# Altium schematic sheet Sheet1 (3).SchDoc("Sheet1 (3)")
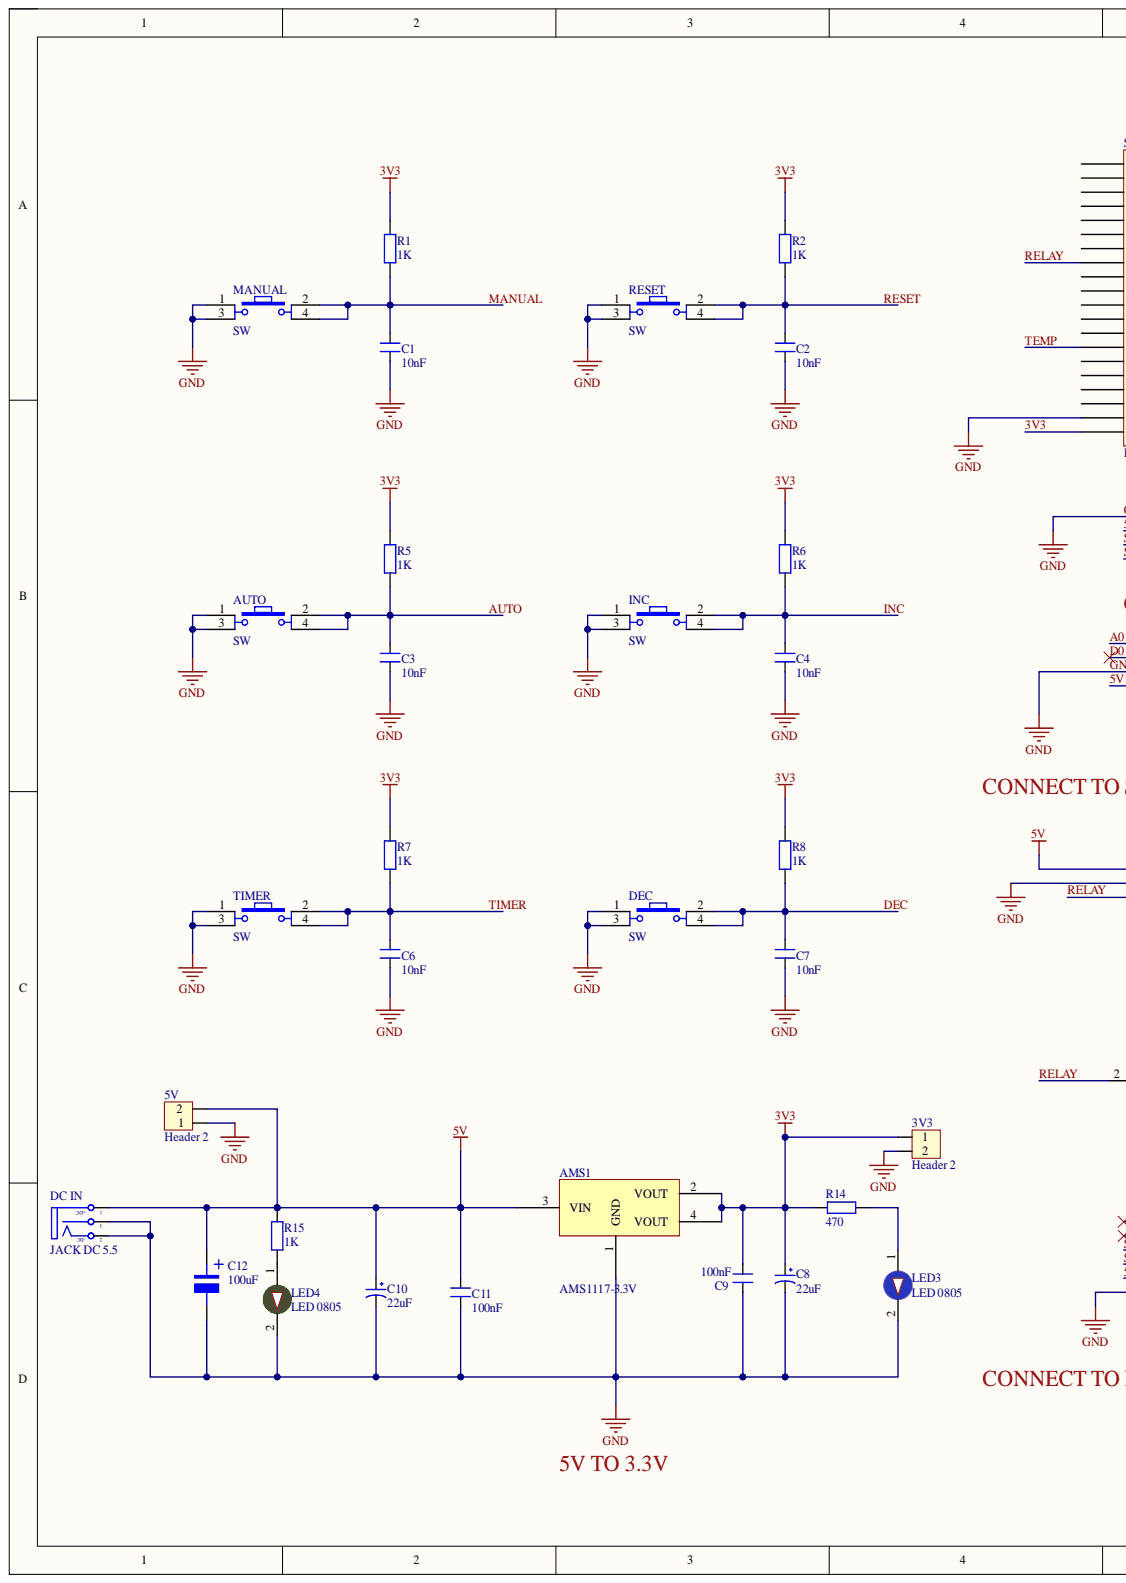
[diagram p0: Sheet1 , left]
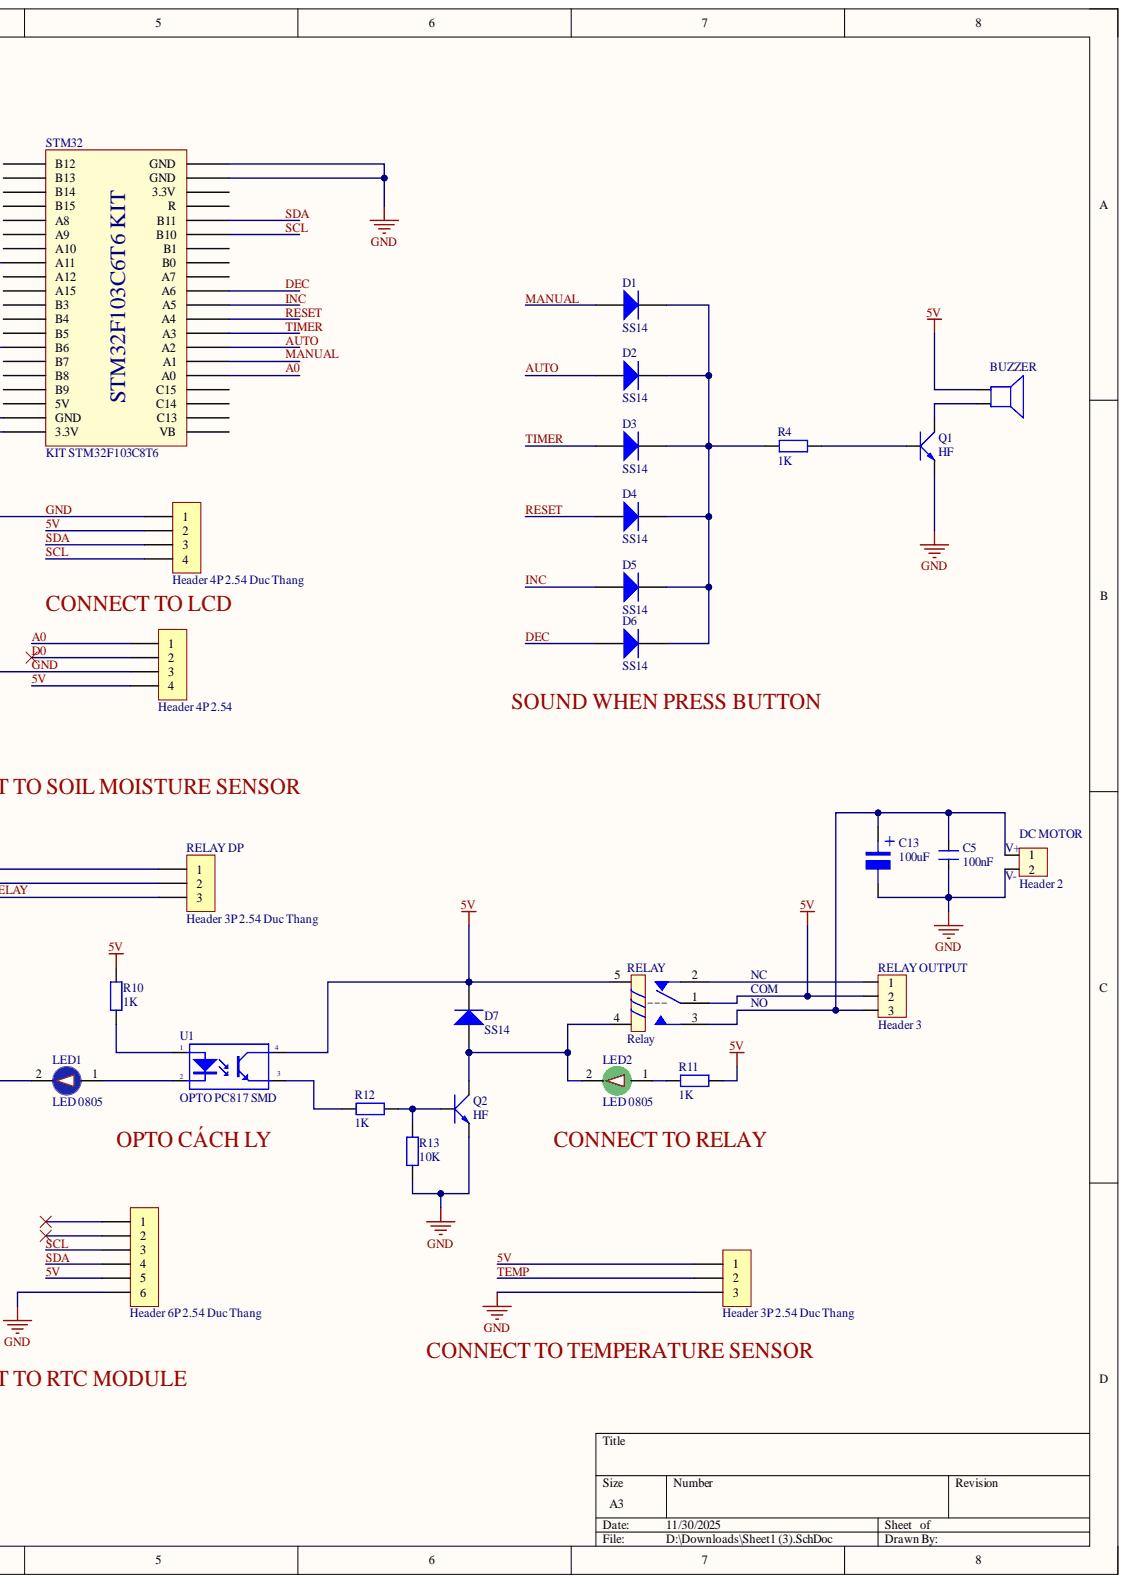
[diagram p1: Sheet1 , right]

## Parts
3V3  Header 2  [HED2]
5V  Header 2  [HED2]
AMS1  AMS1117-3.3V  [SOT223]
AUTO  SW  [SW4]
BUZZER  (no value)  [Coi Chip Speacker]
C1  10nF  [SM-0805]
C2  10nF  [SM-0805]
C3  10nF  [SM-0805]
C4  10nF  [SM-0805]
C5  100nF  [SM-0805]
C6  10nF  [SM-0805]
C7  10nF  [SM-0805]
C8  22uF  [tu tantalum smd3528]
C9  100nF  [SM-0805]
C10  22uF  [tu tantalum smd3528]
C11  100nF  [SM-0805]
C12  100uF  [tu nhom 6.3 (d)]
C13  100uF  [tu nhom 6.3 (d)]
D1  SS14  [DIODE SMA]
D2  SS14  [DIODE SMA]
D3  SS14  [DIODE SMA]
D4  SS14  [DIODE SMA]
D5  SS14  [DIODE SMA]
D6  SS14  [DIODE SMA]
D7  SS14  [DIODE SMA]
DC_IN  JACK DC 5.5  [JACK DC 5.5]
DC_MOTOR  Header 2  [HED2]
DEC  SW  [SW4]
DS3231  Header 6P 2.54 Duc Thang  [XH2.54M-6]
INC  SW  [SW4]
LCD_I2C  Header 4P 2.54 Duc Thang  [XH2.54M-4]
LED1  LED 0805  [LED-0805-Blue]
LED2  LED 0805  [LED-0805-Green]
LED3  LED 0805  [LED-0805-Blue]
LED4  LED 0805  [LED-0805-Green]
MANUAL  SW  [SW4]
MOISTURE  Header 4P 2.54  [XH2.54M-4]
Q1  HF  [SOT-23]
Q2  HF  [SOT-23]
R1  1K  [SM-0805]
R2  1K  [SM-0805]
R4  1K  [SM-0805]
R5  1K  [SM-0805]
R6  1K  [SM-0805]
R7  1K  [SM-0805]
R8  1K  [SM-0805]
R10  1K  [SM-0805]
R11  1K  [SM-0805]
R12  1K  [SM-0805]
R13  10K  [SM-0805]
R14  470  [SM-0805]
R15  1K  [SM-0805]
RELAY  Relay  [Relay 5 Chan SRD]
RELAY_DP  Header 3P 2.54 Duc Thang  [XH2.54M-3]
RELAY_OUTPUT  Header 3  [HED3]
RESET  SW  [SW4]
STM32  KIT STM32F103C8T6  [STM32F103 KIT]
TEMP  Header 3P 2.54 Duc Thang  [XH2.54M-3]
TIMER  SW  [SW4]
U1  OPTO PC817 SMD  value PC817  [SOP4]

## Nets
3V3: 3V3.1 AMS1.2(VOUT) AMS1.4(VOUT) C8.1 C9.2 R1.2 R2.2 R5.2 R6.2 R7.2 R8.2 R14.2 STM32.20(3.3V)
5V: 5V.2 AMS1.3(VIN) BUZZER.1 C10.1 C11.2 C12.1 D7.2 DC_IN.1 DS3231.5 LCD_I2C.2 MOISTURE.4 R10.2 R11.1 R15.1 RELAY.1 RELAY.5 RELAY_DP.1 RELAY_OUTPUT.2 TEMP.1 U1.4
A0: MOISTURE.1 STM32.25(A0)
AUTO: AUTO.2 AUTO.4 C3.2 D2.1 R5.1 STM32.27(A2)
D0: MOISTURE.2
DEC: C7.2 D6.1 DEC.2 DEC.4 R8.1 STM32.31(A6)
GND: 3V3.2 5V.1 AMS1.1(GND) AUTO.1 AUTO.3 C1.1 C2.1 C3.1 C4.1 C5.1 C6.1 C7.1 C8.2 C9.1 C10.2 C11.1 C12.2 C13.2 DC_IN.2 DC_IN.3 DC_MOTOR.2 DEC.1 DEC.3 DS3231.6 INC.1 INC.3 LCD_I2C.1 LED3.2 LED4.2 MANUAL.1 MANUAL.3 MOISTURE.3 Q1.2 Q2.2 R13.2 RELAY_DP.2 RESET.1 RESET.3 STM32.19(GND) STM32.39(GND) STM32.40(GND) TEMP.3 TIMER.1 TIMER.3
INC: C4.2 D5.1 INC.2 INC.4 R6.1 STM32.30(A5)
MANUAL: C1.2 D1.1 MANUAL.2 MANUAL.4 R1.1 STM32.26(A1)
RELAY: LED1.2 RELAY_DP.3 STM32.8(A11)
RESET: C2.2 D4.1 R2.1 RESET.2 RESET.4 STM32.29(A4)
SCL: DS3231.3 LCD_I2C.4 STM32.35(B10)
SDA: DS3231.4 LCD_I2C.3 STM32.36(B11)
TEMP: STM32.14(B6) TEMP.2
TIMER: C6.2 D3.1 R7.1 STM32.28(A3) TIMER.2 TIMER.4
NetBUZZER_2: BUZZER.2 Q1.3
NetC5_2: C5.2 C13.1 DC_MOTOR.1 RELAY.3 RELAY_OUTPUT.3
NetD1_2: D1.2 D2.2 D3.2 D4.2 D5.2 D6.2 R4.2
NetD7_1: D7.1 LED2.2 Q2.3 RELAY.4
NetLED1_1: LED1.1 U1.2
NetLED2_1: LED2.1 R11.2
NetLED3_1: LED3.1 R14.1
NetLED4_1: LED4.1 R15.2
NetQ1_1: Q1.1 R4.1
NetQ2_1: Q2.1 R12.1 R13.1
NetR10_1: R10.1 U1.1
NetR12_2: R12.2 U1.3
NetRELAY OUTPUT_1: RELAY.2 RELAY_OUTPUT.1

Not connected: DS3231 1 2; STM32 1(B12) 2(B13) 3(B14) 4(B15) 5(A8) 6(A9) 7(A10) 9(A12) 10(A15) 11(B3) 12(B4) 13(B5) 15(B7) 16(B8) 17(B9) 18(5V) 21(VB) 22(C13) 23(C14) 24(C15) 32(A7) 33(B0) 34(B1) 37(R) 38(3.3V)

## Library data of the parts
3V3 5V DC_MOTOR = Hed2: Header 2 (Green); Component Kind: Standard; Value: Header 2; Library Name: syhaunguyen.SchLib
AMS1 = AMS1117 SMD: Dán; Don_Vi: Con; Ma_Hang: MH000596; Value: AMS1117-3.3V SOT223; Chung_Loai: Dán; Component Kind: Standard; Library Name: Altium_Lib_by_KhueNguyenCreator.IntLib
AUTO DEC INC MANUAL RESET TIMER = SW4: Switch button 4 pin; Value: Button chip 4 pin; Component Kind: Standard; Library Name: syhaunguyen.SchLib
BUZZER = Coi Chip Speaker: Còi chip; Component Kind: Standard; Library Name: Altium_Lib_by_KhueNguyenCreator.IntLib
C1-C7 C9 C11 = CM: Cap SMD; Value: 103; Component Kind: Standard; Library Name: syhaunguyen.SchLib
C8 C10 = Tu tantalum 100uF 6.3V SMD3528: Dán; Don_Vi: Con; Ma_Hang: MH007595; So_Chan: 2; Ten_Linh_Kien: Tụ tantalum 107J-100uF 6.3V SMD3528; Chung_Loai: Dán; Component Kind: Standard; Library Name: Altium_Lib_by_KhueNguyenCreator.IntLib
C12 C13 = Tu Nhom 100uF 16V: Dán; Don_Vi: Con; Ma_Hang: MH002219; So_Chan: 2; Ten_Linh_Kien: Tụ Nhôm 100uF 16V 6.3x5.4MM; Chung_Loai: Dán; Component Kind: Standard; Library Name: Altium_Lib_by_KhueNguyenCreator.IntLib
D1-D7 = Diode SS14 ( 1N5819): Dán; Don_Vi: Con; Ma_Hang: MH002211; Ten_Linh_Kien: Diode SS14 DO214 Schottky 1A 40V ( 1N5819 DO214); Chung_Loai: Dán; Component Kind: Standard; Library Name: Altium_Lib_by_KhueNguyenCreator.IntLib
DC_IN = JACK DC 5.5: Value: Jac DC; Component Kind: Standard; Description: JACK DC 5.5; Library Name: Altium_Lib_by_KhueNguyenCreator.IntLib
DS3231 = Header 6P 2.54 Duc Thang: Cắm; Don_Vi: Con; Ma_Hang: MH001972; Ten_Linh_Kien: Header 6P XH2.54-6P Đực Thẳng; Chung_Loai: Cắm; Component Kind: Standard; Library Name: Altium_Lib_by_KhueNguyenCreator.IntLib
LCD_I2C MOISTURE = Header 4P 2.54 Duc Thang: Cắm; Don_Vi: Con; Ma_Hang: MH001285; Ten_Linh_Kien: Header 4P XH2.54-4P Đực Thẳng; Chung_Loai: Cắm; Component Kind: Standard; Library Name: Altium_Lib_by_KhueNguyenCreator.IntLib
LED1 LED3 = LED 0805 Xanh Duong: Dán; Don_Vi: Con; Ma_Hang: MH002327; Ten_Linh_Kien: LED 0805 Màu Xanh Dương (Blue); Chung_Loai: Dán; Component Kind: Standard; Library Name: Altium_Lib_by_KhueNguyenCreator.IntLib
LED2 LED4 = LED 0805 Xanh La: Dán; Don_Vi: Con; Ma_Hang: MH002326; Ten_Linh_Kien: LED 0805 Màu Xanh Lá (Green); Chung_Loai: Dán; Component Kind: Standard; Library Name: Altium_Lib_by_KhueNguyenCreator.IntLib
Q1 Q2 = Trans C1815 HF SMD: SOT-23; Value: C9014; Component Kind: Standard; Library Name: Altium_Lib_by_KhueNguyenCreator.IntLib
R1 R2 R4-R8 R10-R12 R15 = RM: Resistor SMD; Component Kind: Standard; Value: 1K; Library Name: syhaunguyen.SchLib
R13 R14 = RM: Resistor SMD; Value: 1K; Component Kind: Standard; Library Name: syhaunguyen.SchLib
RELAY = Relay 5 Chan SRD: Relay 5 Chan; Ma_Hang: MH009374; Ten: Relay 5V10A SRD-05VDC-SL-C Chính Hãng; Component Kind: Standard; Library Name: Altium_Lib_by_KhueNguyenCreator.IntLib
RELAY_DP TEMP = Header 3P 2.54 Duc Thang: Cắm; Don_Vi: Con; Ma_Hang: MH001292; Ten_Linh_Kien: Header 3P XH2.54-3P Đực Thẳng; Chung_Loai: Cắm; Component Kind: Standard; Library Name: Altium_Lib_by_KhueNguyenCreator.IntLib
RELAY_OUTPUT = Hed3: Header 3 (Green); Component Kind: Standard; Value: Header 3; Library Name: syhaunguyen.SchLib
STM32 = STM32f103 Bluepill: KIT STM32F103C8T6 Bluepill; Component Kind: Standard; Library Name: STM32_PRJ.SchLib
U1 = OPTO PC817 SMD: Single Opto; Component Kind: Standard; Library Name: Altium_Lib_by_KhueNguyenCreator.IntLib
